# Altium schematic sheet core.SchDoc(core)
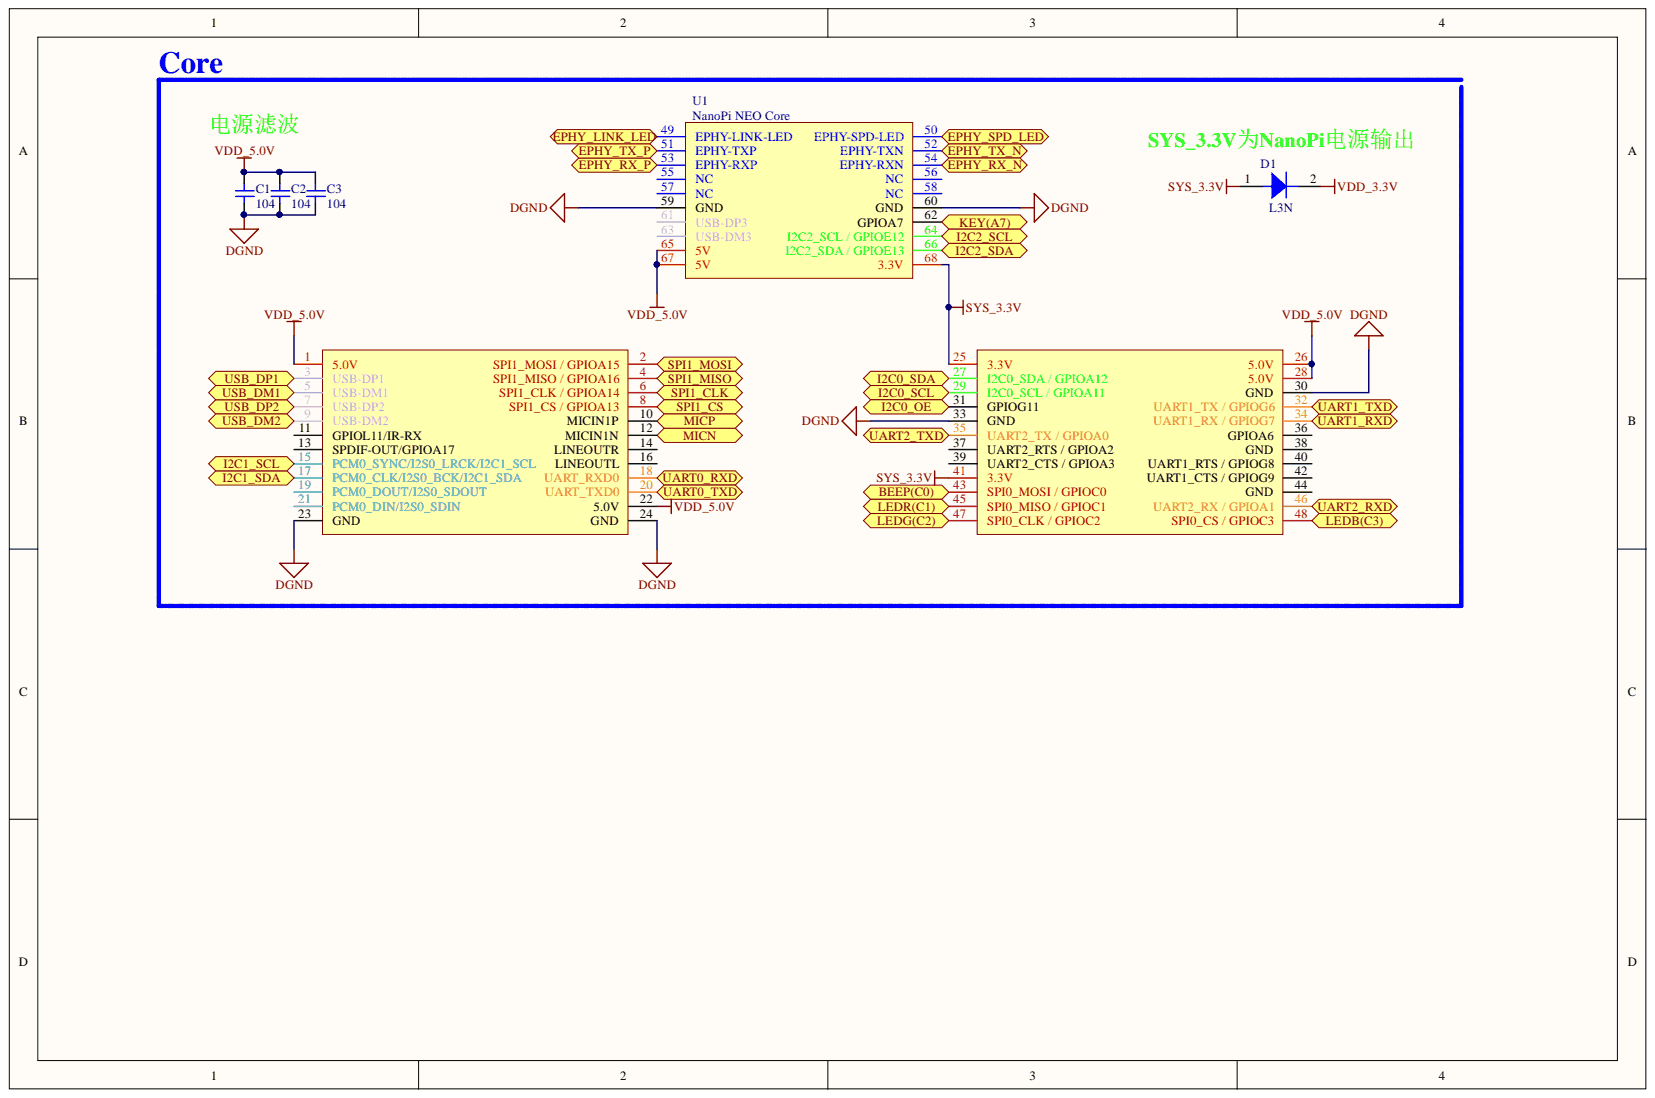
[diagram p0: core.SchDoc]

## Parts
C1  104  [0805C]
C2  104  [0805C]
C3  104  [0805C]
D1  L3N  [SOD-123]
U1  NanoPi NEO Core  [NanoPi NEO Core(无引脚名)]

## Ports
BEEP(C0), EPHY_LINK_LED, EPHY_RX_N, EPHY_RX_P, EPHY_SPD_LED, EPHY_TX_N, EPHY_TX_P, I2C0_OE, I2C0_SCL, I2C0_SDA, I2C1_SCL, I2C1_SDA, I2C2_SCL, I2C2_SDA, KEY(A7), LEDB(C3), LEDG(C2), LEDR(C1), MICN, MICP, SPI1_CLK, SPI1_CS, SPI1_MISO, SPI1_MOSI, UART0_RXD, UART0_TXD, UART1_RXD, UART1_TXD, UART2_RXD, UART2_TXD, USB_DM1, USB_DM2, USB_DP1, USB_DP2

## Nets
DGND: C1.1 C2.1 C3.1 U1.23(GND) U1.24 U1.30(GND) U1.33 U1.59(GND) U1.60(GND)
SYS_3.3V: D1.1 U1.25 U1.41(SYS_3.3V) U1.68(3.3V)
VDD_3.3V: D1.2
VDD_5.0V: C1.2 C2.2 C3.2 U1.1(5.0V) U1.22(VDD_5.0V) U1.26(5.0V) U1.28(5.0V) U1.65(5V) U1.67(5V)
BEEP(C0): U1.43(BEEP(C0)) ; port BEEP(C0)
EPHY_LINK_LED: U1.49(EPHY-LINK-LED) ; port EPHY_LINK_LED
EPHY_RX_N: U1.54(EPHY-RXN) ; port EPHY_RX_N
EPHY_RX_P: U1.53(EPHY-RXP) ; port EPHY_RX_P
EPHY_SPD_LED: U1.50(EPHY-SPD-LED) ; port EPHY_SPD_LED
EPHY_TX_N: U1.52(EPHY-TXN) ; port EPHY_TX_N
EPHY_TX_P: U1.51(EPHY-TXP) ; port EPHY_TX_P
I2C0_OE: U1.31(I2C0_OE) ; port I2C0_OE
I2C0_SCL: U1.29(I2C0_SCL) ; port I2C0_SCL
I2C0_SDA: U1.27(I2C0_SDA) ; port I2C0_SDA
I2C1_SCL: U1.15(PCM0_SYNC/I2S0_LRCK/I2C1_SCL) ; port I2C1_SCL
I2C1_SDA: U1.17(PCM0_CLK/I2S0_BCK/I2C1_SDA) ; port I2C1_SDA
I2C2_SCL: U1.64(I2C2_SCL_/_GPIOE12) ; port I2C2_SCL
I2C2_SDA: U1.66(I2C2_SDA_/_GPIOE13) ; port I2C2_SDA
KEY(A7): U1.62(GPIOA7) ; port KEY(A7)
LEDB(C3): U1.48(SPI0_CS_/_GPIOC3) ; port LEDB(C3)
LEDG(C2): U1.47(LEDG(C2)) ; port LEDG(C2)
LEDR(C1): U1.45(LEDR(C1)) ; port LEDR(C1)
MICN: U1.12 ; port MICN
MICP: U1.10 ; port MICP
SPI1_CLK: U1.6(SPI1_CLK) ; port SPI1_CLK
SPI1_CS: U1.8(SPI1_CS) ; port SPI1_CS
SPI1_MISO: U1.4(SPI1_MISO) ; port SPI1_MISO
SPI1_MOSI: U1.2(SPI1_MOSI) ; port SPI1_MOSI
UART0_RXD: U1.18(UART0_RXD) ; port UART0_RXD
UART0_TXD: U1.20(UART0_TXD) ; port UART0_TXD
UART1_RXD: U1.34(UART1_RX_/_GPIOG7) ; port UART1_RXD
UART1_TXD: U1.32(UART1_TX_/_GPIOG6) ; port UART1_TXD
UART2_RXD: U1.46(UART2_RX_/_GPIOA1) ; port UART2_RXD
UART2_TXD: U1.35(UART2_TXD) ; port UART2_TXD
USB_DM1: U1.5(USB-DM1) ; port USB_DM1
USB_DM2: U1.9(USB-DM2) ; port USB_DM2
USB_DP1: U1.3(USB-DP1) ; port USB_DP1
USB_DP2: U1.7(USB-DP2) ; port USB_DP2

Not connected: U1 11(GPIOL11/IR-RX) 13(SPDIF-OUT/GPIOA17) 14 16 19(PCM0_DOUT/I2S0_SDOUT) 21(PCM0_DIN/I2S0_SDIN) 36(GPIOA6) 37 38(GND) 39 40(UART1_RTS_/_GPIOG8) 42(UART1_CTS_/_GPIOG9) 44(GND) 55(NC) 56(NC) 57(NC) 58(NC) 61(USB-DP3) 63(USB-DM3)

## Library data of the parts
C1-C3 = Cap1: 无极贴片电容; Component Kind: Standard; Library Name: 集大电协1.5.SchLib
D1 = Diode: 二极管30V/2A; Component Kind: Standard; Library Name: 集大电协1.5.SchLib
U1 = NanoPi NEO Core: Component Kind: Standard; Library Name: 集大电协1.5.SchLib
